# Altium schematic sheet STM32F411CCU_revA.SchDoc(STM32F411CCU_revA)
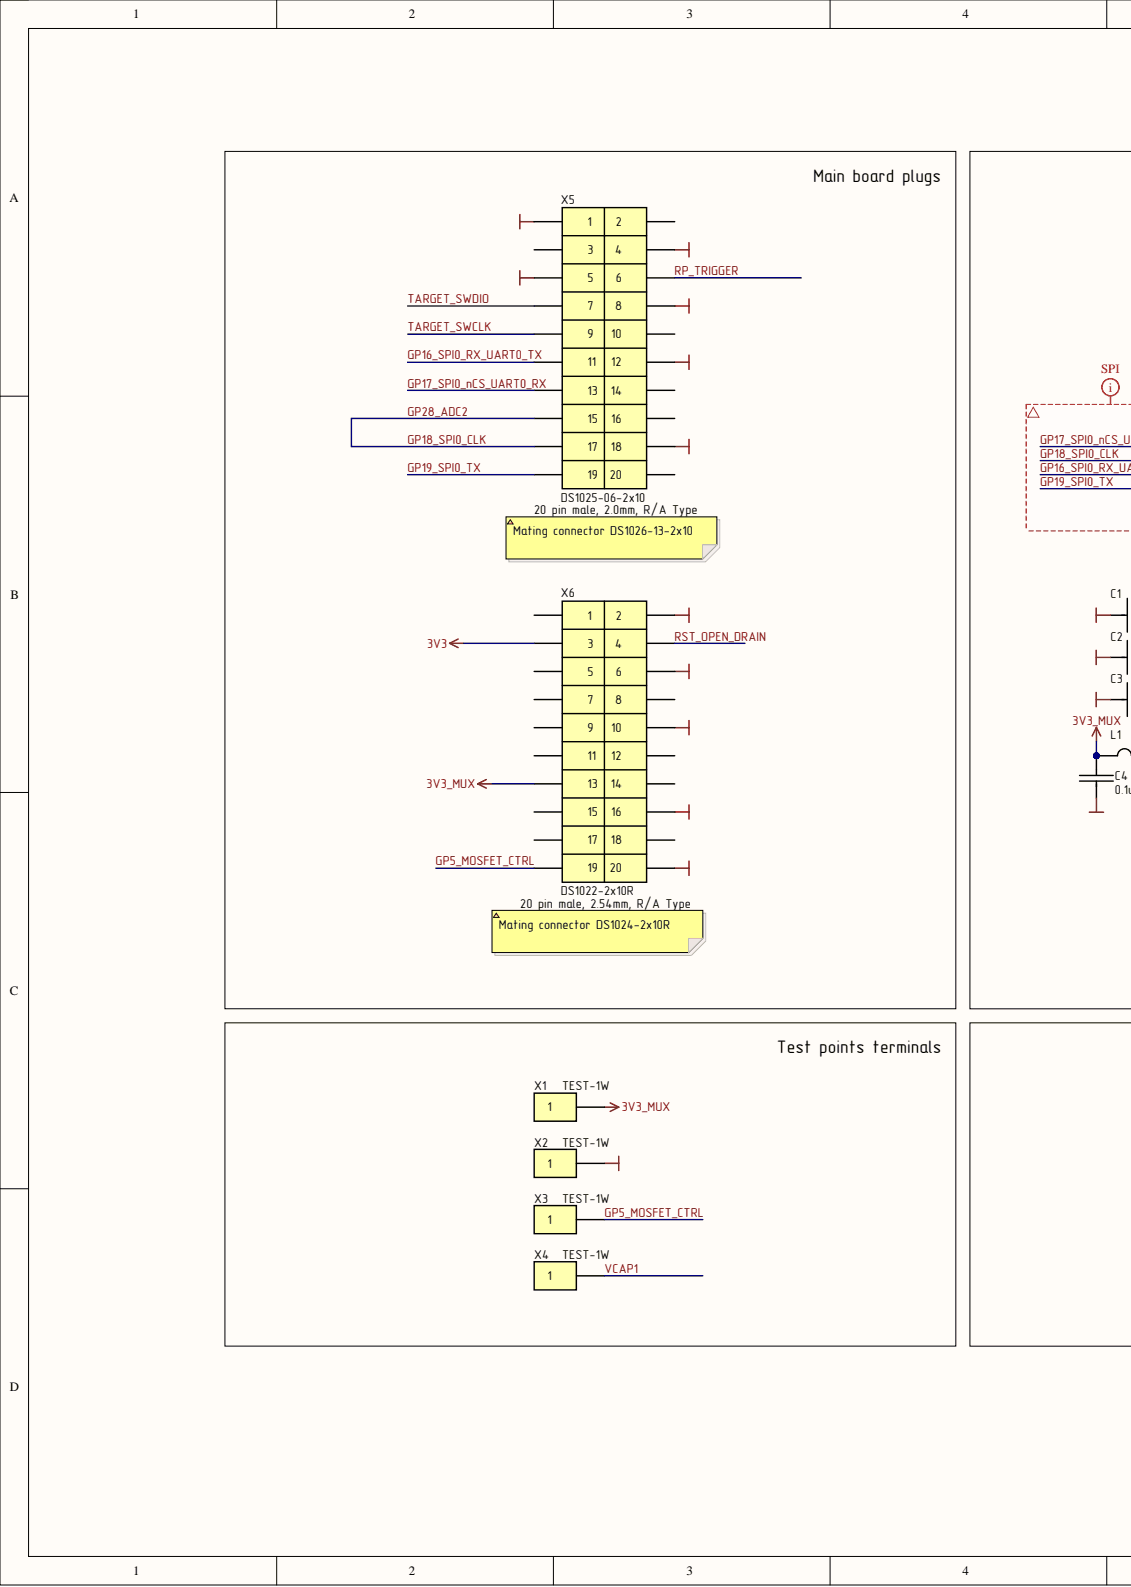
[diagram p0: STM32F411CCU_revA.SchDoc, left]
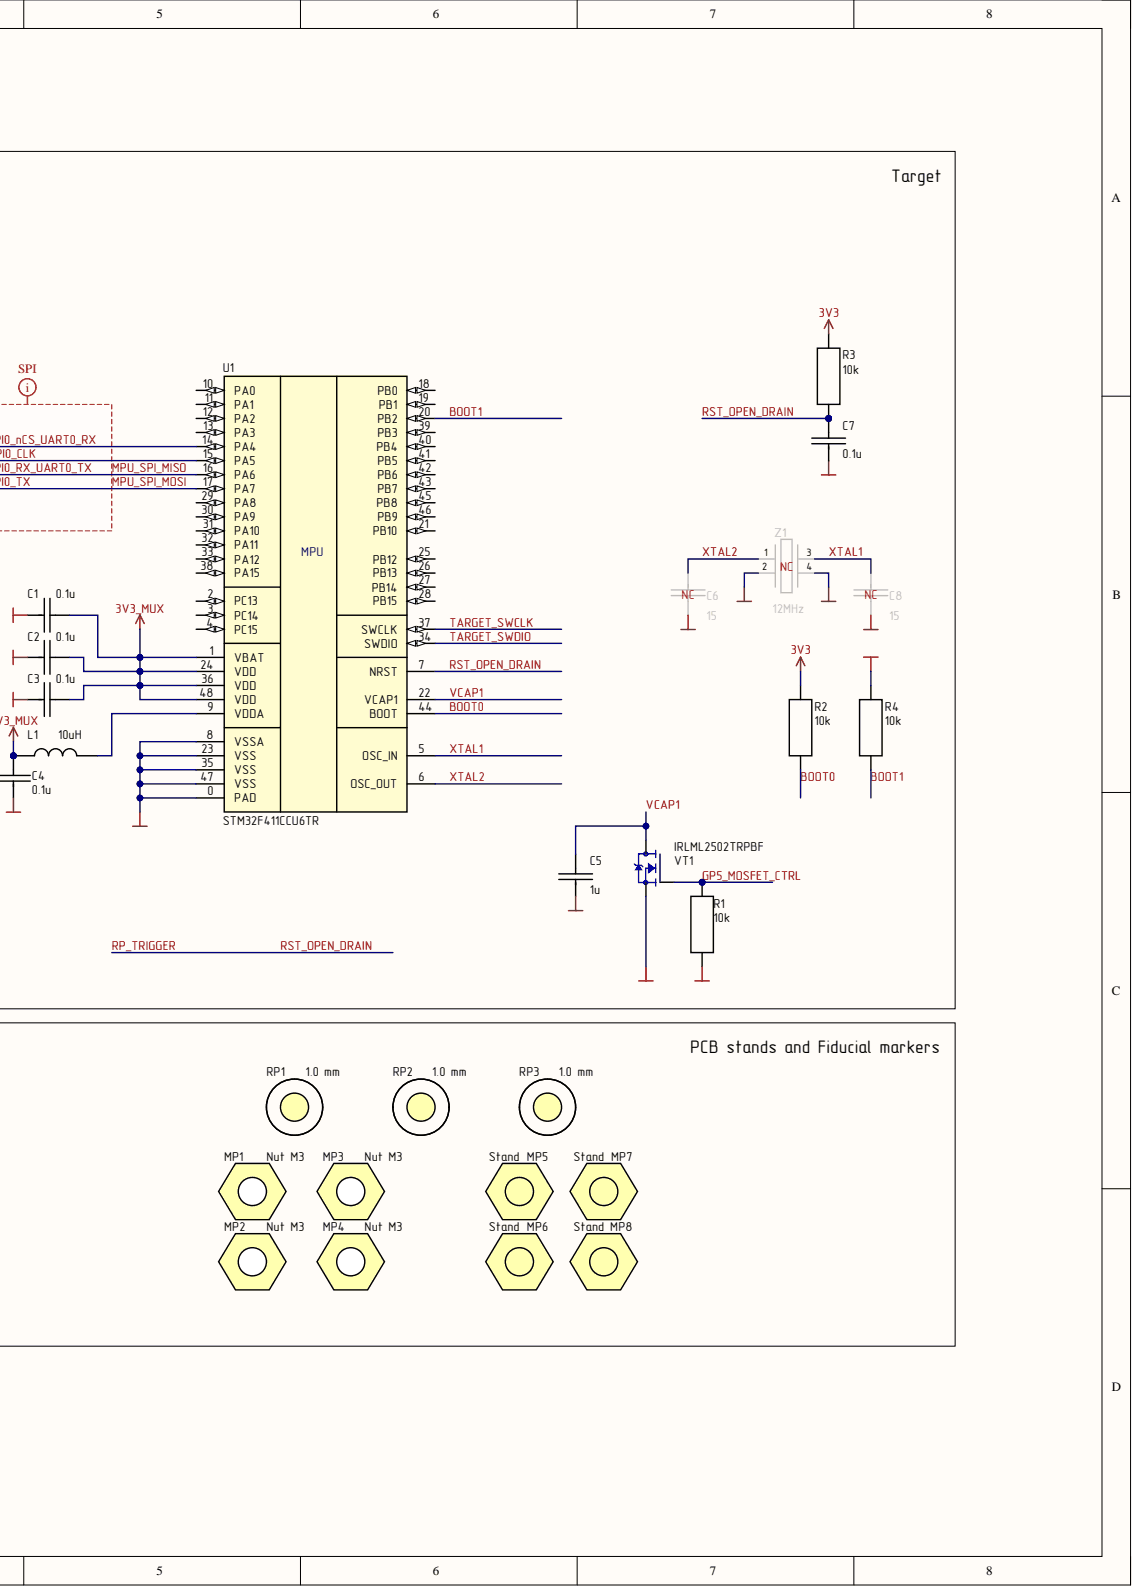
[diagram p1: STM32F411CCU_revA.SchDoc, right]

## Parts
C1  value 0.1u  [CH0603C]  (hidden comment: Ceramic capacitor, 0603, 0.1u, ±10%, 50V, X7R, -55...+125°C)
C2  value 0.1u  [CH0603C]  (hidden comment: Ceramic capacitor, 0603, 0.1u, ±10%, 50V, X7R, -55...+125°C)
C3  value 0.1u  [CH0603C]  (hidden comment: Ceramic capacitor, 0603, 0.1u, ±10%, 50V, X7R, -55...+125°C)
C4  value 0.1u  [CH0603C]  (hidden comment: Ceramic capacitor, 0603, 0.1u, ±10%, 50V, X7R, -55...+125°C)
C5  value 1u  [CH0603C]  (hidden comment: Ceramic capacitor, 0603, 1u, ±10%, 25V, X7R, -55...+125°C)
C6  value 15  [CH0603C]  (hidden comment: Ceramic capacitor, 0603, 15, ±10%, 100V, C0G, -55...+125°C)
C7  value 0.1u  [CH0603C]  (hidden comment: Ceramic capacitor, 0603, 0.1u, ±10%, 50V, X7R, -55...+125°C)
C8  value 15  [CH0603C]  (hidden comment: Ceramic capacitor, 0603, 15, ±10%, 100V, C0G, -55...+125°C)
L1  10uH  [INDC 0603(1608)X95N]
MP1  Nut M3  [Stand]  (mechanical)
MP2  Nut M3  [Stand]  (mechanical)
MP3  Nut M3  [Stand]  (mechanical)
MP4  Nut M3  [Stand]  (mechanical)
MP5  Stand  [Stand]
MP6  Stand  [Stand]
MP7  Stand  [Stand]
MP8  Stand  [Stand]
R1  value 10k  [CH0603R]  (hidden comment: Resistor thick-film, 0603, 10k, ±5%, 0.1W, -55...+125°C)
R2  value 10k  [CH0603R]  (hidden comment: Resistor thick-film, 0603, 10k, ±5%, 0.1W, -55...+125°C)
R3  value 10k  [CH0603R]  (hidden comment: Resistor thick-film, 0603, 10k, ±5%, 0.1W, -55...+125°C)
R4  value 10k  [CH0603R]  (hidden comment: Resistor thick-film, 0603, 10k, ±5%, 0.1W, -55...+125°C)
RP1  1.0 mm  [REPER-10]  (no BOM)
RP2  1.0 mm  [REPER-10]  (no BOM)
RP3  1.0 mm  [REPER-10]  (no BOM)
U1  STM32F411CCU6TR  [BODY%3A UFQFPN48 (7x7) - via 0.2mm]
VT1  IRLML2502TRPBF  [SOT23]
X1  PartNumber: TEST-1W  [TEST-1W 1pin MULTICOMP]  (hidden comment: VDD)
X2  PartNumber: TEST-1W  [TEST-1W 1pin MULTICOMP]  (hidden comment: GND)
X3  GP5  PartNumber: TEST-1W  [TEST-1W 1pin MULTICOMP]
X4  VCAP1  PartNumber: TEST-1W  [TEST-1W 1pin MULTICOMP]
X5  DS1025-06-2x10  [DS1025-06-2x10]
X6  DS1022-2x10R  [DS1022-2x10R]
Z1  12MHz  [OSC: 3225]

## Nets
3V3: R2.2 R3.2 X6.3
3V3_MUX: C1.2 C2.2 C3.2 C4.2 L1.2 U1.1(VBAT) U1.24(VDD) U1.36(VDD) U1.48(VDD) X1.1 X6.13
GND: C1.1 C2.1 C3.1 C4.1 C5.1 C6.2 C7.1 C8.2 R1.2 R4.2 U1.0(PAD) U1.8(VSSA) U1.23(VSS) U1.35(VSS) U1.47(VSS) VT1.2 X2.1 X5.1 X5.4 X5.5 X5.8 X5.12 X5.18 X6.2 X6.6 X6.10 X6.16 X6.20 Z1.2 Z1.4
BOOT0: R2.1 U1.44(BOOT)
BOOT1: R4.1 U1.20(PB2)
GP5_MOSFET_CTRL: R1.1 VT1.1 X3.1 X6.19
GP16_SPI0_RX_UART0_TX: U1.16(PA6) X5.11
GP17_SPI0_nCS_UART0_RX: U1.14(PA4) X5.13
GP18_SPI0_CLK: U1.15(PA5) X5.15 X5.17
GP19_SPI0_TX: U1.17(PA7) X5.19
RP_TRIGGER: C7.2 R3.1 U1.7(NRST) X5.6 X6.4
TARGET_SWCLK: U1.37(SWCLK) X5.9
TARGET_SWDIO: U1.34(SWDIO) X5.7
VCAP1: C5.2 U1.22(VCAP1) VT1.3 X4.1
XTAL1: C8.1 U1.5(OSC_IN) Z1.3
XTAL2: C6.1 U1.6(OSC_OUT) Z1.1
NetL1_1: L1.1 U1.9(VDDA)

Not connected: MP5 1; MP6 1; MP7 1; MP8 1; RP1 1; RP2 1; RP3 1; U1 2(PC13) 3(PC14) 4(PC15) 10(PA0) 11(PA1) 12(PA2) 13(PA3) 18(PB0) 19(PB1) 21(PB10) 25(PB12) 26(PB13) 27(PB14) 28(PB15) 29(PA8) 30(PA9) 31(PA10) 32(PA11) 33(PA12) 38(PA15) 39(PB3) 40(PB4) 41(PB5) 42(PB6) 43(PB7) 45(PB8) 46(PB9); X5 2 3 10 14 16 20; X6 1 5 7 8 9 11 12 14 15 17 18

## Library data of the parts
C1-C4 C7 = Capacitor: Manufacturer: Murata; PartNumber: GRM188R71H104K; Type: SMD; Component Kind: Standard; ComponentType: Ceramic capacitor; Description/Value: Ceramic capacitor, 0603, 0.1u, ±10%, 50V, X7R, -55...+125°C; HelpURL: ?; Library Name: Common Lib.SchLib; Package: 0603; Temperature: -55...+125°C; Tolerance: ±10%; TypeDielectric: X7R; Voltage: 50V
C5 = Capacitor: Manufacturer: Murata; PartNumber: GRM188R71E105KA12D; Type: SMD; Component Kind: Standard; ComponentType: Ceramic capacitor; Description/Value: Ceramic capacitor, 0603, 1u, ±10%, 25V, X7R, -55...+125°C; HelpURL: ?; Library Name: Common Lib.SchLib; Package: 0603; Temperature: -55...+125°C; Tolerance: ±10%; TypeDielectric: X7R; Voltage: 25V
C6 C8 = Capacitor: Manufacturer: Murata; PartNumber: GRM1885C2A150J; Type: SMD; Component Kind: Standard; ComponentType: Ceramic capacitor; Description/Value: Ceramic capacitor, 0603, 15, ±10%, 100V, C0G, -55...+125°C; HelpURL: ?; Library Name: Common Lib.SchLib; Package: 0603; Temperature: -55...+125°C; Tolerance: ±10%; TypeDielectric: C0G; Voltage: 100V
L1 = Inductor: PartNumber: MLZ1608M100WT000; Current: 0.2A; Description/Value: Inductor SMD, 10uH, 0.2A, INDC 0603(1608)X95N; Component Kind: Standard; ComponentType: Inductor SMD; Library Name: Common Lib.SchLib; Value: 10uH
MP1-MP4 = nut: PartNumber: Nut M3 DIN 934; Description/Value: Hexagon Nut M3 DIN 934; Library Name: Common Lib.SchLib
MP5-MP8 = stand: PartNumber: PCHSN-10; Description/Value: PCB brass stand, M3, 10mm; Component Kind: Standard; Library Name: Common Lib.SchLib
R1-R4 = Resistor: Manufacturer: Yageo; PartNumber: RC0603JR-0710KL; Component Kind: Standard; ComponentType: Resistor thick-film; Description/Value: Resistor thick-film, 0603, 10k, ±5%, 0.1W, -55...+125°C; HelpURL: https://datasheet.lcsc.com/lcsc/2009261810_YAGEO-RL0805FR-070R33L_C724024.pdf; Library Name: Common Lib.SchLib; Package: 0603; Power: 0.1W; Temperature: -55...+125°C; Tolerance: ±5%; Type: SMD
RP1-RP3 = CMP-00005-2: Design Item ID: REPER; Source: Common Lib.SchLib; Library Name: Positive Labs Server
U1 = CMP-00115-4: Cortex-M4; Description/Value: IC microcontroller , STM32F411CCU6TR, 48-UFQFPN (7x7), 256KB, 128KB; MemoryRAM: 128KB; MemoryROM: 256KB; Component Kind: Standard; Design Item ID: STM32F411CCU6TR; Package: 48-UFQFPN (7x7); PartNumber: STM32F411CCU6TR; Source: Common Lib.SchLib; Library Name: Positive Labs Server
VT1 = MOSFET N: RoHS Compliant | Industry-leading quality | Fast Switching | Low Profile _less than 1.1mm_ | SOT-23 Footprint; Description/Value: N-MOSFET Transistor, 20V, 4.2A, SOT-23-23; Component Kind: Standard; Library Name: Common Lib.SchLib; Package: SOT-23; PartNumber: IRLML2502TRPBF
X1-X4 = 1-Connector: Description/Value: PCB Test Point Through Hole Mount; Component Kind: Standard; Library Name: Common Lib.SchLib
X5 = 20-Connector: Description/Value: PLD Connector 20 pin, 2.0mm, Male, DS1025-06-2x10; Component Kind: Standard; ComponentKind: PLD Connector 20 pin, 2.0mm, Male; Library Name: Common Lib.SchLib; PartNumber: DS1025-06-2x10
X6 = 20-Connector: Description/Value: PLD Connector 20 pin, 2.54mm, Male, DS1022-2x10R; Component Kind: Standard; ComponentKind: PLD Connector 20 pin, 2.54mm, Male; Library Name: Common Lib.SchLib; PartNumber: DS1022-2x10R
Z1 = Crystal_3225_25MHz: PartNumber: NX3225GA-12MHZ; Description/Value: Crystal, SMD3225C4, 12MHz; Component Kind: Standard; Library Name: Common Lib.SchLib
